# Altium schematic sheet Synthesizer.SchDoc
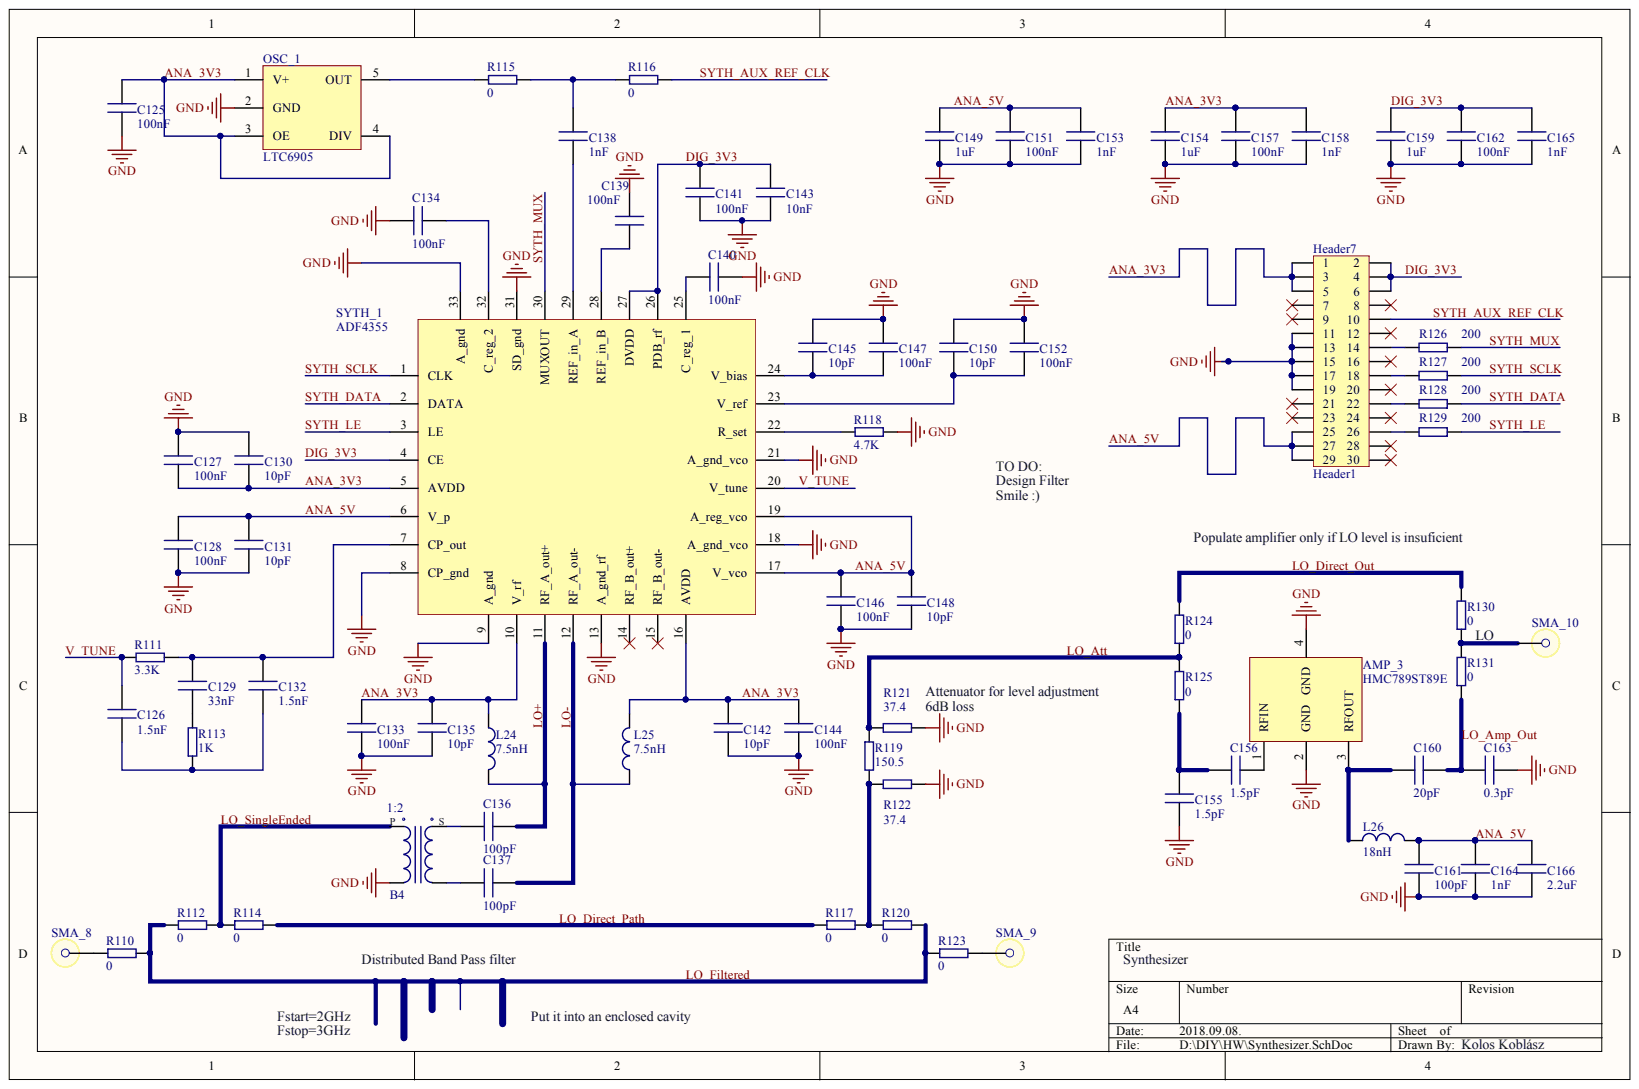
[diagram p0: Synthesizer.SchDoc]

## Parts
AMP_3  HMC789ST89E
B4  ImpedanceTransformation P: 1:2  [SMD]  (hidden comment: Balun)
C125  value 100nF  (hidden comment: Cap1)
C126  value 1.5nF  (hidden comment: Cap1)
C127  value 100nF  (hidden comment: Cap1)
C128  value 100nF  (hidden comment: Cap1)
C129  value 33nF  (hidden comment: Cap1)
C130  value 10pF  (hidden comment: Cap1)
C131  value 10pF  (hidden comment: Cap1)
C132  value 1.5nF  (hidden comment: Cap1)
C133  value 100nF  (hidden comment: Cap1)
C134  value 100nF  (hidden comment: Cap1)
C135  value 10pF  (hidden comment: Cap1)
C136  value 100pF  (hidden comment: Cap1)
C137  value 100pF  (hidden comment: Cap1)
C138  value 1nF  (hidden comment: Cap1)
C139  value 100nF  (hidden comment: Cap1)
C140  value 100nF  (hidden comment: Cap1)
C141  value 100nF  (hidden comment: Cap1)
C142  value 10pF  (hidden comment: Cap1)
C143  value 10nF  (hidden comment: Cap1)
C144  value 100nF  (hidden comment: Cap1)
C145  value 10pF  (hidden comment: Cap1)
C146  value 100nF  (hidden comment: Cap1)
C147  value 100nF  (hidden comment: Cap1)
C148  value 10pF  (hidden comment: Cap1)
C149  value 1uF  (hidden comment: Cap1)
C150  value 10pF  (hidden comment: Cap1)
C151  value 100nF  (hidden comment: Cap1)
C152  value 100nF  (hidden comment: Cap1)
C153  value 1nF  (hidden comment: Cap1)
C154  value 1uF  (hidden comment: Cap1)
C155  value 1.5pF  (hidden comment: Cap1)
C156  value 1.5pF  (hidden comment: Cap1)
C157  value 100nF  (hidden comment: Cap1)
C158  value 1nF  (hidden comment: Cap1)
C159  value 1uF  (hidden comment: Cap1)
C160  value 20pF  (hidden comment: Cap1)
C161  value 100pF  (hidden comment: Cap1)
C162  value 100nF  (hidden comment: Cap1)
C163  value 0.3pF  (hidden comment: Cap1)
C164  value 1nF  (hidden comment: Cap1)
C165  value 1nF  (hidden comment: Cap1)
C166  value 2.2uF  (hidden comment: Cap1)
Header7  Header1  [Header1]
L24  value 7.5nH  [SMD]  (hidden comment: Inductor)
L25  value 7.5nH  [SMD]  (hidden comment: Inductor)
L26  value 18nH  [SMD]  (hidden comment: Inductor)
OSC_1  LTC6905
R110  value 0  [SMD]  (hidden comment: Resistor)
R111  value 3.3K  [SMD]  (hidden comment: Resistor)
R112  value 0  [SMD]  (hidden comment: Resistor)
R113  value 1K  [SMD]  (hidden comment: Resistor)
R114  value 0  [SMD]  (hidden comment: Resistor)
R115  value 0  [SMD]  (hidden comment: Resistor)
R116  value 0  [SMD]  (hidden comment: Resistor)
R117  value 0  [SMD]  (hidden comment: Resistor)
R118  value 4.7K  [SMD]  (hidden comment: Resistor)
R119  value 150.5  [SMD]  (hidden comment: Resistor)
R120  value 0  [SMD]  (hidden comment: Resistor)
R121  value 37.4  [SMD]  (hidden comment: Resistor)
R122  value 37.4  [SMD]  (hidden comment: Resistor)
R123  value 0  [SMD]  (hidden comment: Resistor)
R124  value 0  [SMD]  (hidden comment: Resistor)
R125  value 0  [SMD]  (hidden comment: Resistor)
R126  value 200  [SMD]  (hidden comment: Resistor)
R127  value 200  [SMD]  (hidden comment: Resistor)
R128  value 200  [SMD]  (hidden comment: Resistor)
R129  value 200  [SMD]  (hidden comment: Resistor)
R130  value 0  [SMD]  (hidden comment: Resistor)
R131  value 0  [SMD]  (hidden comment: Resistor)
SMA_8  SMA1  (value not drawn)
SMA_9  SMA1  (value not drawn)
SMA_10  SMA1
SYTH_1  ADF4355

## Nets
GND: AMP_3.2(GND) AMP_3.4(GND) B4.4 C125.2 C127.2 C128.2 C130.2 C131.2 C133.2 C134.2 C135.2 C139.1 C140.2 C141.2 C142.2 C143.2 C144.2 C145.2 C146.2 C147.2 C148.2 C149.1 C150.2 C151.1 C152.2 C153.1 C154.1 C155.1 C157.1 C158.1 C159.1 C161.2 C162.1 C163.2 C164.2 C165.1 C166.2 Header7.11 Header7.13 Header7.15 Header7.17 Header7.19 OSC_1.2(GND) R118.2 R121.2 R122.2 SYTH_1.8(CP_gnd) SYTH_1.9(A_gnd) SYTH_1.13(A_gnd_rf) SYTH_1.18(A_gnd_vco) SYTH_1.21(A_gnd_vco) SYTH_1.31(SD_gnd) SYTH_1.33(A_gnd)
ANA_3V3: C125.1 C127.1 C130.1 C133.1 C135.1 C142.1 C144.1 C154.2 C157.2 C158.2 Header7.1 Header7.3 Header7.5 L24.1 L25.2 OSC_1.1(V+) OSC_1.3(OE) OSC_1.4(DIV) SYTH_1.5(AVDD) SYTH_1.10(V_rf) SYTH_1.16(AVDD)
ANA_5V: C128.1 C131.1 C146.1 C148.1 C149.2 C151.2 C153.2 C161.1 C164.1 C166.1 Header7.25 Header7.27 Header7.29 L26.2 SYTH_1.6(V_p) SYTH_1.17(V_vco) SYTH_1.19(A_reg_vco)
DIG_3V3: C141.1 C143.1 C159.2 C162.2 C165.2 Header7.2 Header7.4 Header7.6 SYTH_1.4(CE) SYTH_1.26(PDB_rf) SYTH_1.27(DVDD)
LO+: C136.2 L24.2 SYTH_1.11(RF_A_out+)
LO-: C137.2 L25.1 SYTH_1.12(RF_A_out-)
LO_AMP_OUT: C160.2 C163.1 R131.1
LO_ATT: R119.1 R121.1 R124.1 R125.2
LO_DIRECT_OUT: R124.2 R130.2
LO_DIRECT_PATH: R114.2 R117.1
LO_FILTERED: R110.2 R112.1 R120.2 R123.1
LO_SINGLEENDED: B4.2 R112.2 R114.1
SYTH_AUX_REF_CLK: Header7.10 R116.2
SYTH_DATA: R128.1 SYTH_1.2(DATA)
SYTH_LE: R129.1 SYTH_1.3(LE)
SYTH_MUX: R126.1 SYTH_1.30(MUXOUT)
SYTH_SCLK: R127.1 SYTH_1.1(CLK)
V_TUNE: C126.1 R111.2 SYTH_1.20(V_tune)
NetAMP_3_1: AMP_3.1(RFIN) C156.2
NetAMP_3_3: AMP_3.3(RFOUT) C160.1 L26.1
NetB4_1: B4.1 C136.1
NetB4_3: B4.3 C137.1
NetC126_2: C126.2 C132.2 R113.1
NetC129_1: C129.1 C132.1 R111.1 SYTH_1.7(CP_out)
NetC129_2: C129.2 R113.2
NetC134_1: C134.1 SYTH_1.32(C_reg_2)
NetC138_1: C138.1 R115.2 R116.1
NetC138_2: C138.2 SYTH_1.29(REF_in_A)
NetC139_2: C139.2 SYTH_1.28(REF_in_B)
NetC140_1: C140.1 SYTH_1.25(C_reg_1)
NetC145_1: C145.1 C147.1 SYTH_1.24(V_bias)
NetC150_1: C150.1 C152.1 SYTH_1.23(V_ref)
NetC155_2: C155.2 C156.1 R125.1
NetHeader7_14: Header7.14 R126.2
NetHeader7_18: Header7.18 R127.2
NetHeader7_22: Header7.22 R128.2
NetHeader7_26: Header7.26 R129.2
NetOSC_1_5: OSC_1.5(OUT) R115.1
NetR110_1: R110.1 SMA_8.1
NetR117_2: R117.2 R119.2 R120.1 R122.1
NetR118_1: R118.1 SYTH_1.22(R_set)
NetR123_2: R123.2 SMA_9.1
NetR130_1: R130.1 R131.2 SMA_10.1

Not connected: Header7 7 8 9 12 16 20 21 23 24 28 30; SYTH_1 14(RF_B_out+) 15(RF_B_out-)

## Library data of the parts
AMP_3 = HMC789ST89E: Gain Block MMIC; Manufacturer: Analog Devices; Component Kind: Standard; Library Name: RadioProjectSchLib.SchLib; Order Code: HMC789ST89E; Package Description: SOT89; PartNumber: HMC789ST89E
B4 = Balun4: SMD Balun; Manufacturer: TDK; PartNumber: HHM1918A2; MaxFrequency: 2700MHz; MinFrequency: 2300MHz; Component Kind: Standard; Library Name: RadioProjectSchLib.SchLib
C125-C166 = Cap1: SMD Capacitor; MaxVolt: 16V; Precision: 1%; Component Kind: Standard; Library Name: RadioProjectSchLib.SchLib
Header7 = Header1: 29 Pin Header; Manufacterer: Samtec; PartNumber: TLW-115-01-G-D-002-RA; PinNumber: 29; Pitch: 2.54mm; Row: 2; Component Kind: Standard; Library Name: RadioProjectSchLib.SchLib; Type: 90°
L24-L26 = Ind1: SMD Inductor; MaxCurent: 100mA; Precision: 10%; Component Kind: Standard; Library Name: RadioProjectSchLib.SchLib
OSC_1 = LTC6905: Fixed frequency oscillator; Manufacturer: Analog Devices; Order Code: LTC6905-100; Component Kind: Standard; Library Name: RadioProjectSchLib.SchLib; Package Description: 5-Lead Plastic TSOT-23; PartNumber: LTC6905-100
R110-R131 = Res1: SMD Resistor; MaxPower: 0.1W; Precision: 1%; Component Kind: Standard; Library Name: RadioProjectSchLib.SchLib
SMA_8-SMA_10 = SMA1: SMA Female; Shape: 90°; Component Kind: Standard; Library Name: RadioProjectSchLib.SchLib; Mounting: TroughHole
SYTH_1 = ADF4355: Sythesizer with integrated VCO; Manufacturer: Analog Devices; Order Code: ADF4355-2BCPZ; Component Kind: Standard; Library Name: RadioProjectSchLib.SchLib; Package Description: 32-Lead Lead Frame Chip Sccale Package; PartNumber: ADF4355
